# Altium schematic sheet power.SchDoc(power)
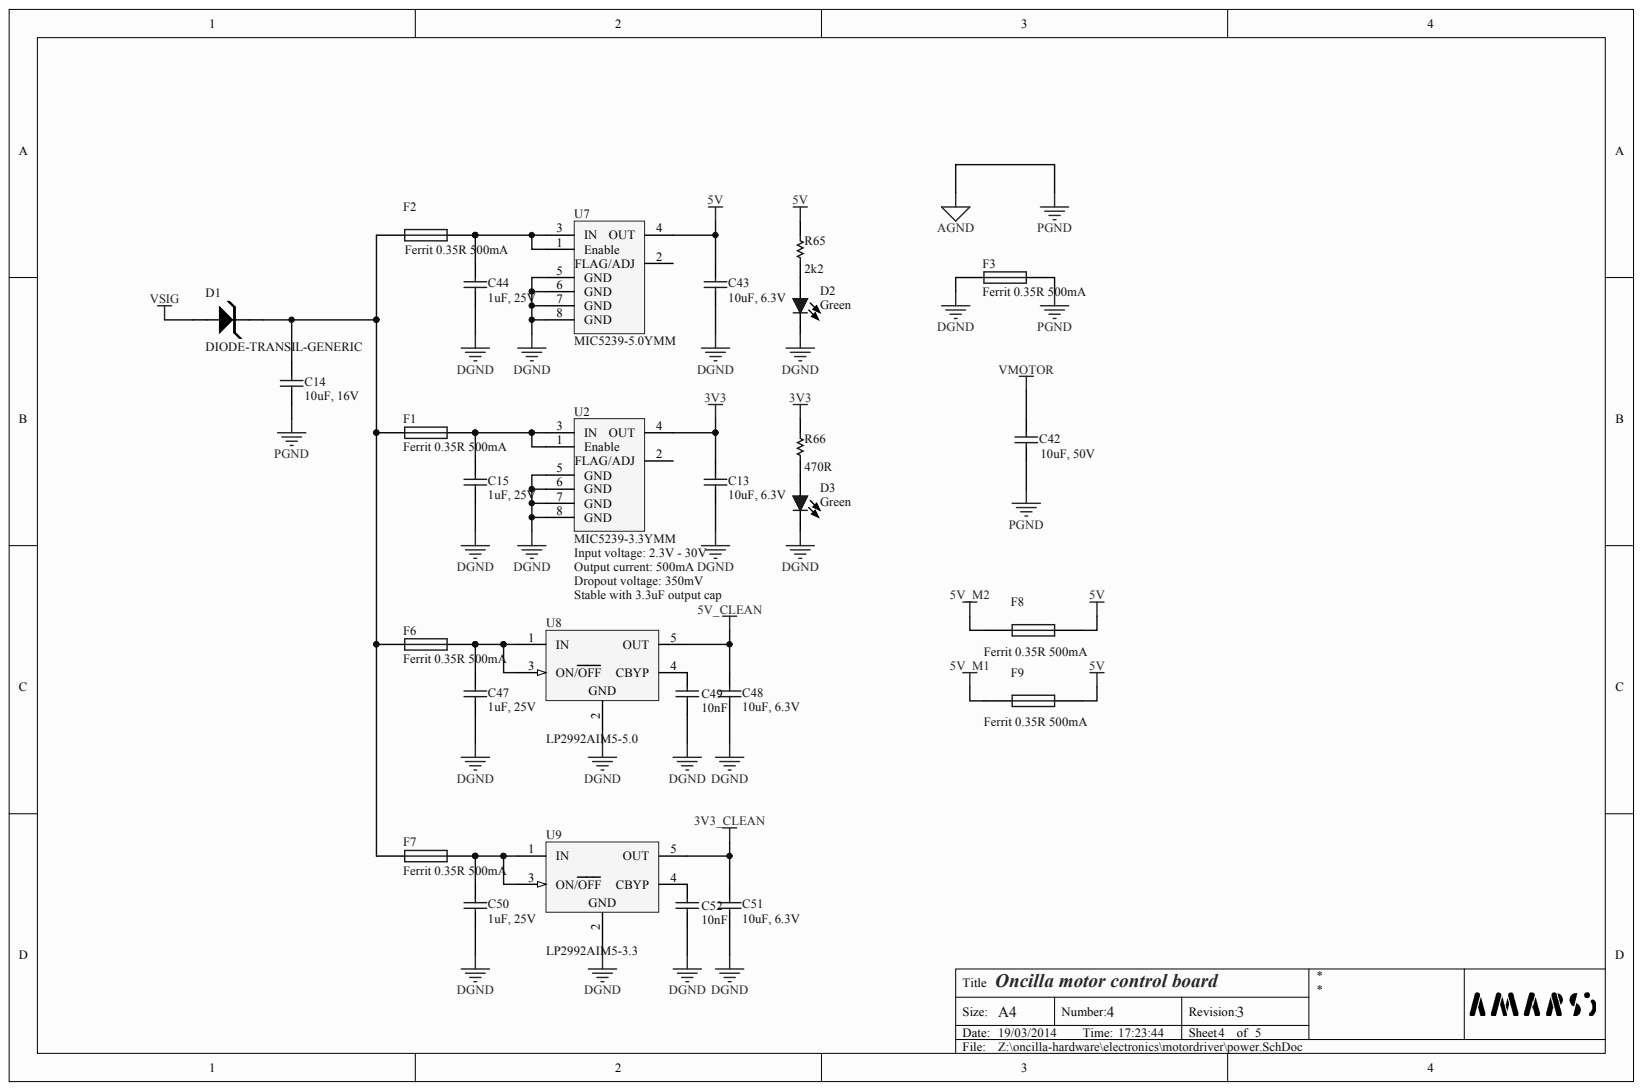
[diagram p0: power.SchDoc]

## Parts
C13  10uF, 6.3V
C14  10uF, 16V
C15  1uF, 25V
C42  10uF, 50V
C43  10uF, 6.3V
C44  1uF, 25V
C47  1uF, 25V
C48  10uF, 6.3V
C49  10nF
C50  1uF, 25V
C51  10uF, 6.3V
C52  10nF
D1  DIODE-TRANSIL-GENERIC
D2  Color: Green  (hidden comment: LED Green)
D3  Color: Green  (hidden comment: LED Green)
F1  Ferrit 0.35R 500mA
F2  Ferrit 0.35R 500mA
F3  Ferrit 0.35R 500mA
F6  Ferrit 0.35R 500mA
F7  Ferrit 0.35R 500mA
F8  Ferrit 0.35R 500mA
F9  Ferrit 0.35R 500mA
R65  2k2
R66  470R
U2  MIC5239-3.3YMM
U7  MIC5239-5.0YMM
U8  LP2992AIM5-5.0
U9  LP2992AIM5-3.3

## Nets
3V3: C13.2 R66.2 U2.4(OUT)
3V3_CLEAN: C51.2 U9.5(OUT)
5V: C43.2 F8.2 F9.2 R65.2 U7.4(OUT)
5V_CLEAN: C48.2 U8.5(OUT)
5V_M1: F9.1
5V_M2: F8.1
AGND: C14.1 C42.1 F3.2
DGND: C13.1 C15.1 C43.1 C44.1 C47.1 C48.1 C49.1 C50.1 C51.1 C52.1 D2.2 D3.2 F3.1 U2.5(GND) U2.6(GND) U2.7(GND) U2.8(GND) U7.5(GND) U7.6(GND) U7.7(GND) U7.8(GND) U8.2 U9.2
VMOTOR: C42.2
VSIG: D1.A
NetC14_2: C14.2 D1.K F1.1 F2.1 F6.1 F7.1
NetC15_2: C15.2 F1.2 U2.1(Enable) U2.3(IN)
NetC44_2: C44.2 F2.2 U7.1(Enable) U7.3(IN)
NetC47_2: C47.2 F6.2 U8.1(IN) U8.3(ON/OFF)
NetC49_2: C49.2 U8.4(CBYP)
NetC50_2: C50.2 F7.2 U9.1(IN) U9.3(ON/OFF)
NetC52_2: C52.2 U9.4(CBYP)
NetD2_1: D2.1 R65.1
NetD3_1: D3.1 R66.1

Not connected: U2 2(FLAG/ADJ); U7 2(FLAG/ADJ)

## Library data of the parts
U8 = (no symbol name): Manufacturer: National Semiconductor; CompType: LDO IC; PackageTopMarking: LFFA*XTT; PowerWise: N; Published: 4-Dec-2008; DatasheetVersion: May-2005; PackageVersion: revD, Aug-2008; PackageReference: MF05A; PackageDescription: Molded SOT-23, .115 x .063 x .040 in Body, 5 Lead, .0375 in Pitch; PartNumber: LP2992AIM5-5.0; RoHS: O; PinCount: 5; DigiKeyPartNumber: LP2992AIM5-5.0CT-ND; ComponentLink1Description: LP2992 Datasheet; ComponentLink1URL: http://www.national.com/ds/LP/LP2992.pdf; ComponentLink2Description: LP2992 Web Page; ComponentLink2URL: http://www.national.com/pf/LP/LP2992.html; ComponentLink3Description: MF05A Package Page; ComponentLink3URL: http://www.national.com/packaging/folders/mf05a.html; Publisher: Altium Limited
U9 = (no symbol name): PartNumber: LP2992AIM5-5.0; Manufacturer: National Semiconductor; CompType: LDO IC; PackageTopMarking: LFFA*XTT; PowerWise: N; Published: 4-Dec-2008; DatasheetVersion: May-2005; PackageVersion: revD, Aug-2008; PackageReference: MF05A; PackageDescription: Molded SOT-23, .115 x .063 x .040 in Body, 5 Lead, .0375 in Pitch; RoHS: O; PinCount: 5; DigiKeyPartNumber: LP2992AIM5-5.0CT-ND; ComponentLink1Description: LP2992 Datasheet; ComponentLink1URL: http://www.national.com/ds/LP/LP2992.pdf; ComponentLink2Description: LP2992 Web Page; ComponentLink2URL: http://www.national.com/pf/LP/LP2992.html; ComponentLink3Description: MF05A Package Page; ComponentLink3URL: http://www.national.com/packaging/folders/mf05a.html; Publisher: Altium Limited
C13-C15 C42-C44 C47-C52 D1-D3 F1-F3 F6-F9 R65 R66 U2 U7 = (no symbol name)
  C13 C43 C48 C51: Published: 8-Jun-2000; LatestRevisionDate: 29-May-2009; LatestRevisionNote: IPC-7351 Footprint Added.; PackageReference: C1206; PackageDescription: Chip Capacitor; Value: 10uF, 6.3V
  C14: Published: 8-Jun-2000; LatestRevisionDate: 29-May-2009; LatestRevisionNote: IPC-7351 Footprint Added.; PackageReference: C1206; PackageDescription: Chip Capacitor; Value: 10uF, 16V
  C15 C44 C47 C50: Published: 8-Jun-2000; LatestRevisionDate: 29-May-2009; LatestRevisionNote: IPC-7351 Footprint Added.; PackageReference: C1206; PackageDescription: Chip Capacitor; Value: 1uF, 25V
  C42: Published: 8-Jun-2000; LatestRevisionDate: 29-May-2009; LatestRevisionNote: IPC-7351 Footprint Added.; PackageReference: C1206; PackageDescription: Chip Capacitor; Value: 10uF; Voltage: 50V
  C49 C52: Published: 8-Jun-2000; LatestRevisionDate: 29-May-2009; LatestRevisionNote: IPC-7351 Footprint Added.; PackageReference: C1206; PackageDescription: Chip Capacitor; Value: 10nF
  F1-F3 F6-F9: Published: 8-Jun-2000; LatestRevisionDate: 17-Jul-2002; LatestRevisionNote: Re-released for DXP Platform.; PackageReference: PIN-W2/E2.8
  R65: Published: 8-Jun-2000; LatestRevisionDate: 17-Jul-2002; LatestRevisionNote: Re-released for DXP Platform.; PackageReference: AXIAL-0.5; Value: 2k2
  R66: Published: 8-Jun-2000; LatestRevisionDate: 17-Jul-2002; LatestRevisionNote: Re-released for DXP Platform.; PackageReference: AXIAL-0.5; Value: 470R
  C13-C15 C42-C44 C47-C52 F1-F3 F6-F9 R65 R66: Publisher: Altium Limited
